# Altium schematic sheet Controller.SchDoc
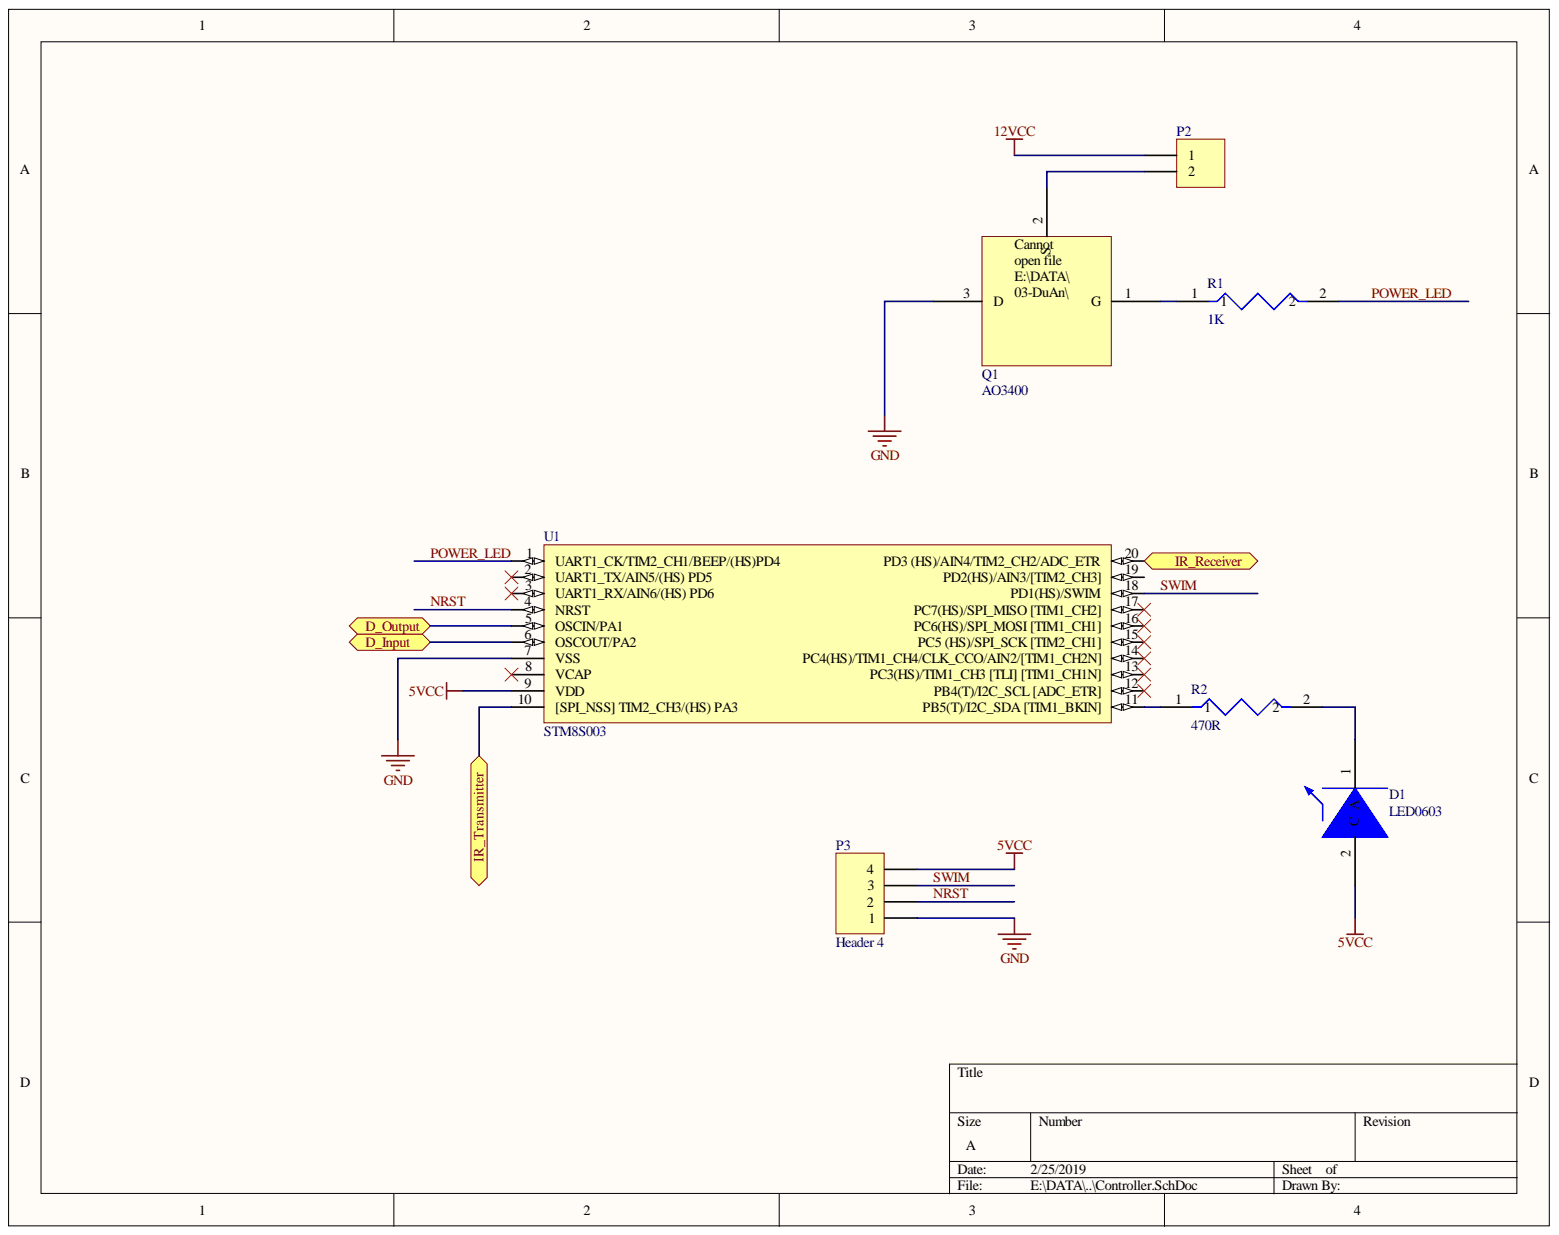
[diagram p0: Controller.SchDoc]

## Parts
D1  LED0603  [DIOC1608X06N]
P2  (no value)  [HDR1X2]
P3  Header 4  [HDR1X4]
Q1  AO3400  [SOT95P278X125-3N]
R1  1K  [RESC2608X06N_0603]
R2  470R  [RESC2608X06N_0603]
U1  STM8S003  [TSOP65P640X120-20N]

## Ports
D_Input, D_Output, IR_Receiver, IR_Transmitter

## Nets
5VCC: D1.2 P3.4 U1.9(VDD)
12VCC: P2.1
GND: P3.1 Q1.3 U1.7(VSS)
D_Input: U1.6(OSCOUT/PA2) ; port D_Input
D_Output: U1.5(OSCIN/PA1) ; port D_Output
IR_Receiver: U1.20(PD3_(HS)/AIN4/TIM2_CH2/ADC_ETR) ; port IR_Receiver
IR_Transmitter: U1.10([SPI_NSS]_TIM2_CH3/(HS)_PA3) ; port IR_Transmitter
NRST: P3.2 U1.4(NRST)
POWER_LED: R1.2 U1.1(UART1_CK/TIM2_CH1/BEEP/(HS)PD4)
SWIM: P3.3 U1.18(PD1(HS)/SWIM)
NetD1_1: D1.1 R2.2
NetP2_2: P2.2 Q1.2
NetQ1_1: Q1.1 R1.1
NetR2_1: R2.1 U1.11(PB5(T)/I2C_SDA_[TIM1_BKIN])

Not connected: U1 2(UART1_TX/AIN5/(HS)_PD5) 3(UART1_RX/AIN6/(HS)_PD6) 8(VCAP) 12(PB4(T)/I2C_SCL_[ADC_ETR]) 13(PC3(HS)/TIM1_CH3_[TLI]_[TIM1_CH1N]) 14(PC4(HS)/TIM1_CH4/CLK_CCO/AIN2/[TIM1_CH2N]) 15(PC5_(HS)/SPI_SCK_[TIM2_CH1]) 16(PC6(HS)/SPI_MOSI_[TIM1_CH1]) 17(PC7(HS)/SPI_MISO_[TIM1_CH2]) 19(PD2(HS)/AIN3/[TIM2_CH3])

## Library data of the parts
P2 = Header 2: Header, 2-Pin; Component Kind: Standard; LatestRevisionDate: 17-Jul-2002; LatestRevisionNote: Re-released for DXP Platform.; Library Name: Miscellaneous Connectors.IntLib; Signal Integrity: Connector; Publisher: Altium Limited
P3 = Header 4: Header, 4-Pin; Component Kind: Standard; LatestRevisionDate: 17-Jul-2002; LatestRevisionNote: Re-released for DXP Platform.; Library Name: Miscellaneous Connectors.IntLib; Signal Integrity: Connector; Publisher: Altium Limited
Q1 = AO3400  MOSFET SOT23-3: Component Kind: Standard; Description: AO3400  MOSFET SOT23-3; Library Name: Integrated_Library1.IntLib
R1 = 1k_0603_5%: Thick Film Resistors - SMD 0603 1kOHMS 5% 1/10WATT; Component Kind: Standard; Library Name: Integrated_Library1.IntLib; Supplier 1: Digi-Key; Supplier Part Number 1: CR0603-JW-101ELFCT-ND
R2 = R470_0603_5%: Thick Film Resistors - SMD 470 ohm 5%; Component Kind: Standard; Library Name: Integrated_Library1.IntLib; Supplier 1: Digi-Key; Supplier Part Number 1: CR0603-JW-471ELFCT-ND
U1 = STM8S003: MCU 8-bit STM8S STM8 CISC 8KB Flash 3.3V/5V 20-Pin TSSOP T/R; Value: STM8S003F3; Component Kind: Standard; Library Name: Integrated_Library1.IntLib; Supplier Part Number 1: 497-18051-1-ND
D1 = LED0603: Component Kind: Standard; Description: LED0603; Library Name: Integrated_Library1.IntLib
